# Altium schematic sheet Schematic Prints("All Documents",Physical)
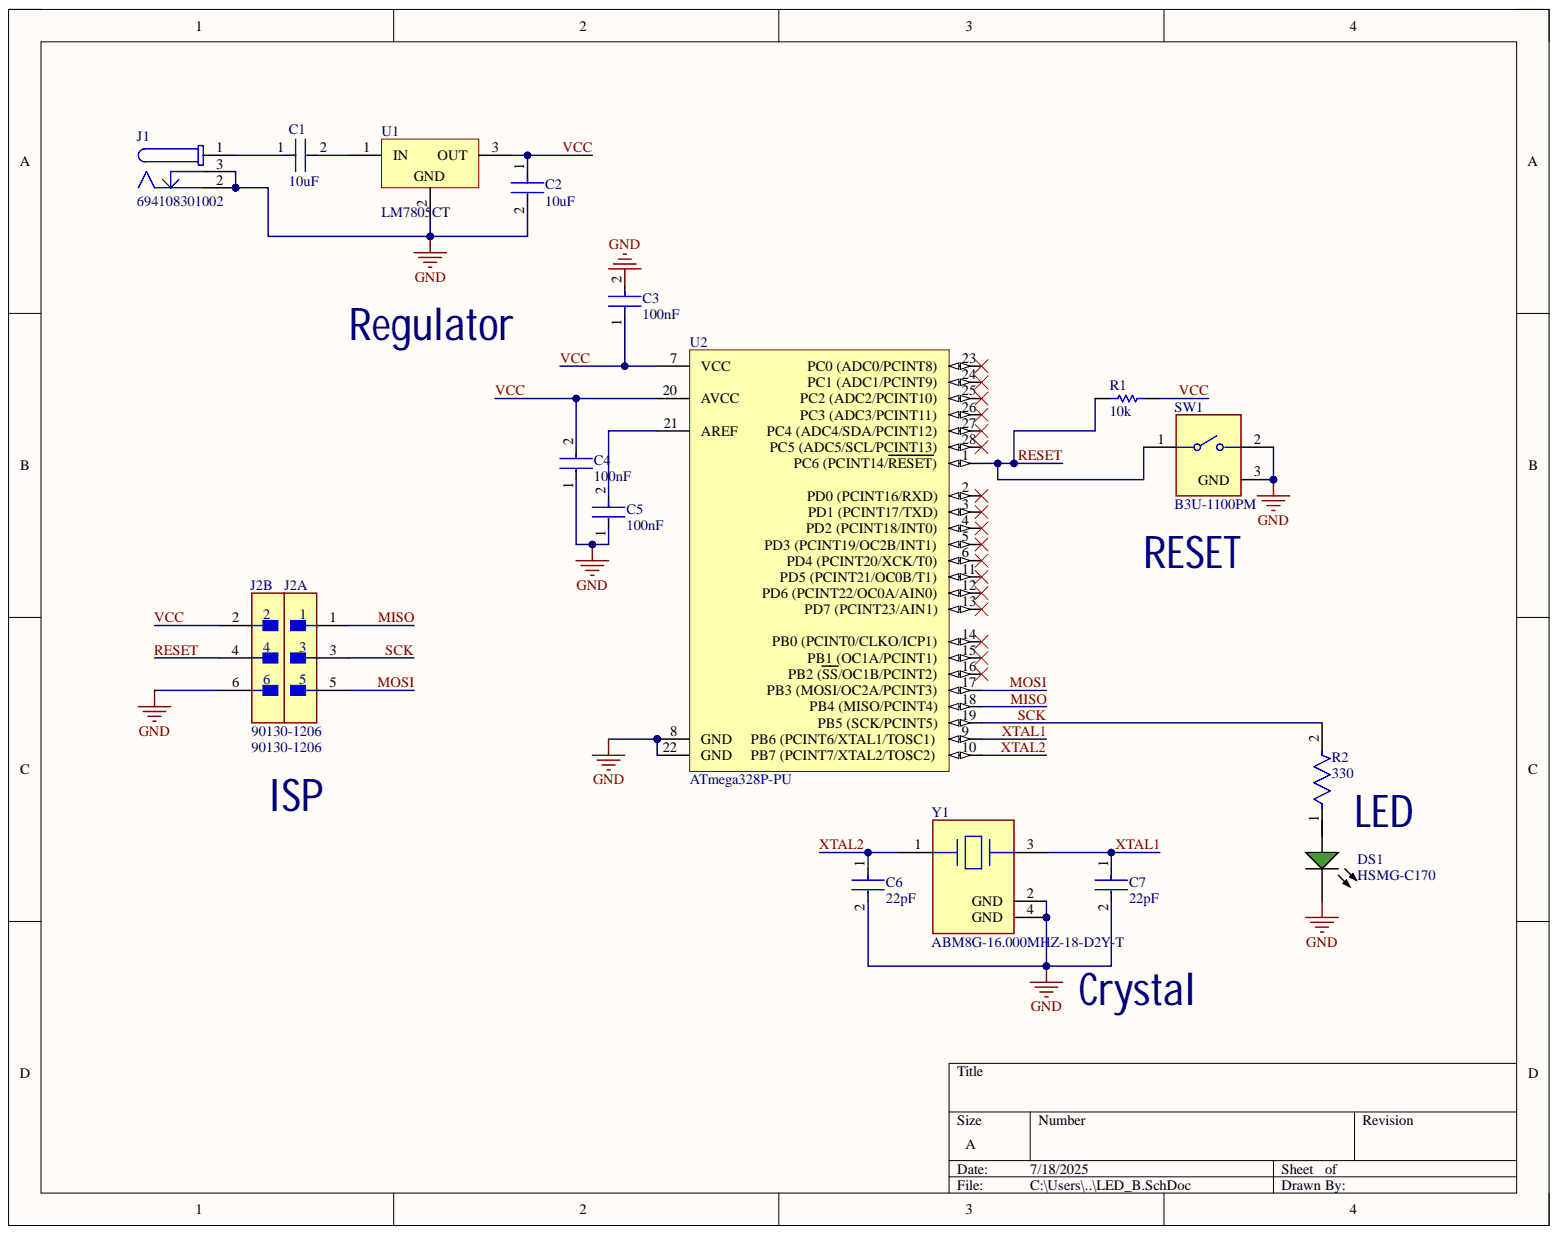
[diagram p0: Schematic Prints("All Documents",Physical)]

## Parts
C1  10uF  [FP-GRM21B-0_1-IPC_C]
C2  10uF  [FP-GRM21B-0_1-IPC_C]
C3  100nF  [FP-GRM15-MFG]
C4  100nF  [FP-GRM15-MFG]
C5  100nF  [FP-GRM15-MFG]
C6  22pF  [FP-C0603C-CF-MFG]
C7  22pF  [FP-C0603C-CF-MFG]
DS1  HSMG-C170  [AVAG-HSMX-C170_V]
J1  694108301002  [694108301002]
J2  90130-1206  [FP-90130-1206-MFG]  (parts A,B)
R1  10k  [RESC1005X40X25LL05T10]
R2  330  [FP-CRCW0805-e3-MFG]
SW1  B3U-1100PM  [FP-B3U-1100PM-MFG]
U1  LM7805CT  [FAIR-TO-220-3]
U2  ATmega328P-PU  [28P3]
Y1  ABM8G-16.000MHZ-18-D2Y-T  [FP-ABM8G-MFG]

## Nets
GND: C2.2 C3.2 C4.1 C5.1 C6.2 C7.2 DS1.2 J1.2 J1.3 J2.6 SW1.2 SW1.3 U1.2 U2.8(GND) U2.22(GND) Y1.2(GND) Y1.4(GND)
MISO: J2.1 U2.18(PB4_(MISO/PCINT4))
MOSI: J2.5 U2.17(PB3_(MOSI/OC2A/PCINT3))
RESET: J2.4 R1.1 SW1.1 U2.1(PC6_(PCINT14/RESET))
SCK: J2.3 R2.2 U2.19(PB5_(SCK/PCINT5))
VCC: C2.1 C3.1 C4.2 J2.2 R1.2 U1.3 U2.7(VCC) U2.20(AVCC)
XTAL1: C7.1 U2.9 Y1.3
XTAL2: C6.1 U2.10 Y1.1
NetC1_1: C1.1 J1.1
NetC1_2: C1.2 U1.1
NetC5_2: C5.2 U2.21(AREF)
NetDS1_1: DS1.1
NetR2_1: R2.1
NetU2_2: U2.2(PD0_(PCINT16/RXD))
NetU2_3: U2.3(PD1_(PCINT17/TXD))
NetU2_4: U2.4(PD2_(PCINT18/INT0))
NetU2_5: U2.5(PD3_(PCINT19/OC2B/INT1))
NetU2_6: U2.6(PD4_(PCINT20/XCK/T0))
NetU2_11: U2.11(PD5_(PCINT21/OC0B/T1))
NetU2_12: U2.12(PD6_(PCINT22/OC0A/AIN0))
NetU2_13: U2.13(PD7_(PCINT23/AIN1))
NetU2_14: U2.14(PB0_(PCINT0/CLKO/ICP1))
NetU2_15: U2.15(PB1_(OC1A/PCINT1))
NetU2_16: U2.16(PB2_(SS/OC1B/PCINT2))
NetU2_23: U2.23(PC0_(ADC0/PCINT8))
NetU2_24: U2.24(PC1_(ADC1/PCINT9))
NetU2_25: U2.25
NetU2_26: U2.26(PC3_(ADC3/PCINT11))
NetU2_27: U2.27
NetU2_28: U2.28(PC5_(ADC5/SCL/PCINT13))

## Library data of the parts
C1 C2 = CMP-2007-03453-2: Chip Multilayer Ceramic Capacitors for General Purpose, 0805, 10uF, X5R, 15%, 10%, 16V; Capacitance: 10uF; Case Code (Imperial): 0805; Case Code (Metric): 2012; Case/Package: 0805; Component Kind: Standard; Depth: 1.25mm; Dielectric: X5R; Height: 1.25mm; Lead Free: Lead Free; Length: 2mm; Library Name: Altium Content Vault; Material: Ceramic; Max Operating Temperature: 85°C; Min Operating Temperature: -55°C; Mount: Surface Mount; Package Quantity: 1; Packaging: Tape and Reel; Radiation Hardening: No; REACH SVHC: No SVHC; Termination: SMD/SMT; Thickness: 0.053inch; Tolerance: 10%; Voltage: 16V; Voltage Rating: 16V; Voltage Rating (DC): 16V; Width: 1.25mm
C3-C5 = CMP-2100-03641-2: CAP Ceramic 0.10μF 10% X7R 16Vdc 0402 SMD; Manufacturer: Murata; Manufacturer Part Number: GRM155R71C104KA88D; Standoff Height: 0mm; Capacitance: 0.10μF; Category: Cap; Component Kind: Standard; ComponentLink2Description: Datasheet; ComponentLink2URL: https://search.murata.co.jp/Ceramy/image/img/A01X/G101/ENG/GRM155R71H471KA01-01A.pdf; Device Class L1: Passive Components; Device Class L2: Capacitors; Device Class L3: Ceramic Capacitors; Digikey Description: CAP CER 0.1UF 16V X7R 0402; Height: 0.55mm; Ipc Land Pattern Name: CAPC10050X219; Lead Free: yes; Library Name: Altium Content Vault; Material: Ceramic; Mouser Description: 0402 0.1 uF 16V ±10 % Tolerance X7R SMT Multilayer Ceramic Capacitor; Octopart: https://octopart.com/grm155r71c104ka88d-murata-196880; Package: GRM15; RoHS: TRUE; Temperature: -55°C to +125°C; Temperature Characteristic: X7R; Temperature Range High: +125°C; Temperature Range Low: -55°C; Tolerance: 10%; Value: 0.10μF; Voltage Rating: 16Vdc
C6 C7 = CMP-2006-03465-2: C0603 22 pF C0G 30ppm/°C 10.00% 16 V; Manufacturer: KEMET; Manufacturer Part Number: C0603C220K4GACTU; Automotive Grade: Grade 1; Material: Ceramic; Tolerance: 0.1; Voltage Rating: 16 V; Automotive: Yes; Capacitance: 22 pF; Category: Cap; Component Kind: Standard; ComponentLink2Description: Datasheet; ComponentLink2URL: https://api.kemet.com/component-edge/download/datasheet/C0603C100J5GACAUTO.pdf; Device Class L1: Passive Components; Device Class L2: Capacitors; Device Class L3: Ceramic Capacitors; Digikey Description: CAP CER 22PF 16V 10% NP0 0603; Height: 0.87mm; Lead Free: Yes; Library Name: Altium Content Vault; Mouser Description: Multilayer Ceramic Capacitors MLCC - SMD/SMT 16volts 22pF C0G 10%; Octopart: https://octopart.com/c0603c220k4gactu-kemet-17097913; Package: C0603C-CF; RoHS: TRUE; Temperature: -55°C to +125°C; Temperature Characteristic: C0G; Temperature Coefficient: 30ppm/°C; Temperature Range High: +125°C; Temperature Range Low: -55°C; Value: 22 pF
DS1 = CMP-2000-05062-1: Chip LED, Green, 2.2 V, -40 to 85 degC, 2-Pin SMD, Pb-Free, Tape and Reel; Manufacturer: Avago; Bulb Size: 1.25mmx1.4mm; Dominant Wavelength: 572nm; External Depth: 0.8mm; External Length / Height: 2mm; External Width: 1.25mm; Forward Current If: 20mA; Forward Voltage: 2.2V; Forward Voltage VF Max: 2.6V; LED Type: Standard; Lens Shape: Rectangular; Light Area Height: 1mm; Luminous Intensity: 15mcd; Luminous Intensity Min: 4mcd; No. of Pins: 2; Opto Mounting Orientation: Top; Peak Wavelength: 570nm; PIV Max: 5V; SVHC: NoSVHC(16-Jun-2014); Viewing Angle: 170deg; Component Kind: Standard; Datasheet URL: https://datasheet.ciiva.com/29280/180495-da-01-en-chip-led-hsmg-c170-gelb-gruen-29280971.pdf; Datasheet Version: Oct-08; Dominant Wavelength Typ: 572nm; Forward Current If Max: 20mA; LED Colour: Green; LED Mounting: SMD; Library Name: Altium Content Vault; Luminous Intensity Typ: 15mcd; Manufacturer URL: http://www.avagotech.com/; Mounting Type: SMD; MSL: MSL2A-4weeks; Operating Temperature Max: 85degC; Operating Temperature Min: -30degC; Operating Temperature Range: -30degCto+85degC; Opto Case Style: SMD; Package Description: 2-Pin Surface Mount Device, Body 2 x 1.25 mm, Height 0.8 mm; Package Reference: HSMX-C170; Packaging: CutTape; Peak Forward Current: 20mA; Storage Temperature Max: 85degC; Storage Temperature Min: -40degC; Voltage Vf Typ: 2.2V; Wavelength Typ: 570nm
J1 = CMP-1502-00190-3: NexarPartId: 52676102; Connector Type: Power; Current Rating: 5A; Gender: Male; Insulation Resistance: 100MΩ; Number of Contacts: 3; Operating Supply Voltage: 24V; Shielding: Unshielded; Voltage Rating (DC): 20V; Color: Black; Component Kind: Standard; Contact Gender: Female; Contact Material: Gold, Tin; Contact Plating: Tin; Flammability Rating: UL94 V-0; Height: 11mm; Housing Material: Polyamide; Library Name: Altium Content Vault; Max Operating Temperature: 85°C; Min Operating Temperature: -40°C; Mount: Through Hole; Packaging: Bulk; REACH SVHC: Unknown; RoHS: Compliant; Termination: Through Hole; Voltage Rating: 24V
J2 = CMP-04776-000468-1: CONN HEADER VERT 6POS 2.54MM; Contact Resistance: 20mR; Current Rating: 3A; Gender: Male; Glow Wire Compliant: Non-compliant; Insulation Resistance: 5GR; Max Current Rating: 3.9A; Max Voltage Rating (AC): 500V; Max Voltage Rating (DC): 350.35kV; Number of Circuits: 6; Voltage Rating: 350V; Color: Black; Component Kind: Standard; Contact Gender: Male; Contact Material: Brass; Contact Plating: Gold; Depth: 3.94mm; Height: 4.7mm; Housing Color: Black; Housing Material: Polyester; Lead Free: Lead Free; Lead Pitch: 2.54mm; Length: 8mm; Library Name: Altium Content Vault; Material: Glass; Max Operating Temperature: 125°C; Min Operating Temperature: -55°C; Mount: Through Hole; Number of Contacts: 6; Number of Poles: 6; Number of Positions: 6; Number of Rows: 2; Orientation: Vertical; Package Quantity: 2560; Packaging: Tray; Pitch: 2.54mm; Radiation Hardening: No; Row Spacing: 2.54mm; Series: 90130; Termination: Solder; Voltage Rating (AC): 350V; Voltage Rating (DC): 350V; Working Voltage: 350V
R1 = CMP-2002-07353-1: Manufacturer: Yageo / Phycomp; Part Number: RC0402FR-0710KL; Component Kind: Standard; Library Name: Altium Content Vault
R2 = CMP-2001-03823-2: RES Thick Film, 330Ω, 1%, 0.125W, 100ppm/°C, 0805; Max Power Dissipation: 125mW; Resistance: 330R; Voltage Rating: 150V; Case Code (Imperial): 0805; Case Code (Metric): 2012; Case/Package: 0805; Component Kind: Standard; Composition: Thick Film; Contact Plating: Tin; Depth: 1.25mm; Features: Automotive AEC-Q200; Height: 0.02inch; Lead Free: Lead Free; Length: 2mm; Library Name: Altium Content Vault; Max Operating Temperature: 155°C; Military Standard: Not; Min Operating Temperature: -55°C; Mount: Surface Mount; Number of Terminations: 2; Package Quantity: 10; Packaging: Tape and Reel; Power Rating: 125mW; Temperature Coefficient: 100ppm/°C; Termination: SMD/SMT; Tolerance: 1%; Voltage Rating (DC): 150V; Weight: 0.000194oz; Width: 0.049inch
SW1 = CMP-08834-000028-1: SWITCH TACTILE SPST-NO 0.05A 12V; Actuator Length: 0.4mm; Actuator Type: Standard; Connector Type: SMD/SMT; Contact Resistance: 100mR; Current Rating: 50mA; Electrical Life: 200000Cycles; Illumination Type: Non-Illuminated; Operating Force: 1.5N; Ratings: Dust Proof; Switch Function: Off-Mom; Switch Travel: 0.15mm; Throw Configuration: SPST; Case/Package: SMD/SMT; Component Kind: Standard; Library Name: Altium Content Vault; Max Operating Temperature: 70°C; Max Voltage Rating (DC): 12V; Mechanical Life: 200000Cycles; Min Operating Temperature: -25°C; Mount: Surface Mount; Orientation: Straight; Package Quantity: 1000; Packaging: Tape and Reel; REACH SVHC: No SVHC; RoHS Compliant: Yes; Series: B3U; Termination: SMD/SMT; Voltage Rating (DC): 12V; Weight: 0.022g
U1 = CMP-2000-04938-1: Positive Voltage Regulator, 5 V, 1 A, -40 to 125 degC, 3-Pin TO-220, RoHS, Tube; Manufacturer: FairchildSemiconductor; Accuracy: ±4%; Line Regulation: 100mV; Maximum Input Voltage: 35V; Maximum Output Current: 2.2(Typ)A; Maximum Quiescent Current: 8mA; Number of Outputs: 1; Output Type: Fixed; Output Voltage: 5V; Rad Hard: No; Typical Dropout Voltage @ Current: 2@1AV; Category: LinearRegulator; Component Kind: Standard; Datasheet URL: https://datasheet.ciiva.com/26904/lm7805ct-26904988.pdf; Datasheet Version: Aug-12; Library Name: Altium Content Vault; Load Regulation: 100mV; Manufacturer URL: https://www.fairchildsemi.com/; Mounting: ThroughHole; Operating Temperature: -40to125degC; Package: 3TO-220AB; Package Description: 3-Pin Through Hole Device, Body 10 x 4.5 mm, Pitch 2.54 mm; Package Reference: TO-220; Package URL: https://www.fairchildsemi.com/package-drawings/TO/TO220B03.pdf; Packaging: Rail/Tube; Polarity: Positive; Type: Linear
U2 = CMP-0095-00013-2: 8-bit AVR Microcontroller, 32KB Flash, 1KB EEPROM, 2KB SRAM, 28-pin PDIP, Industrial Grade (-40°C to 85°C); Component Kind: Standard; ComponentLink1Description: Manufacturer URL; ComponentLink1URL: http://www.atmel.com/; ComponentLink2Description: ATmega48A/48PA/88A/88PA/168A/168PA/328/328P Datasheet; ComponentLink2URL: http://www.atmel.com/dyn/resources/prod_documents/8271.pdf; ComponentLink3Description: ATmega48A/48PA/88A/88PA/168A/168PA/328/328P Summary; ComponentLink3URL: http://www.atmel.com/dyn/resources/prod_documents/8271S.pdf; DatasheetVersion: revD, May-2011; Library Name: Altium Content Vault; PackageDescription: 28-lead (0.300"/7.62mm Wide) Plastic Dual Inline Package (PDIP); PackageReference: 28P3; PackageVersion: revB, Sep-2001; RevisionNote: DatasheetVersion updated. URLs added.
Y1 = CMP-27762-006537-2: Crystal 16MHz ±20ppm (Tol) ±30ppm (Stability) 18pF FUND 80Ω 4-Pin SMD T/R; ESR (Equivalent Series Resistance): 80R; Case/Package: CSMD; Component Kind: Standard; Depth: 2.5mm; Frequency: 16MHz; Frequency Stability: 30ppm; Frequency Tolerance: 20ppm; Height: 1mm; Lead Free: Lead Free; Length: 3.2mm; Library Name: Altium Content Vault; Load Capacitance: 18pF; Max Operating Temperature: 85°C; Min Operating Temperature: -40°C; Mount: Surface Mount; Number of Pins: 4; Operating Frequency: 16 MHz; Package Quantity: 1000; Packaging: Tape and Reel; Radiation Hardening: No; Series: ABM8G; Series Resistance: 80R; Termination: SMD/SMT; Tolerance: 20ppm; Width: 2.5mm
